# Altium schematic sheet sdflowmeterdisplay2.SchDoc(sdflowmeterdisplay2)
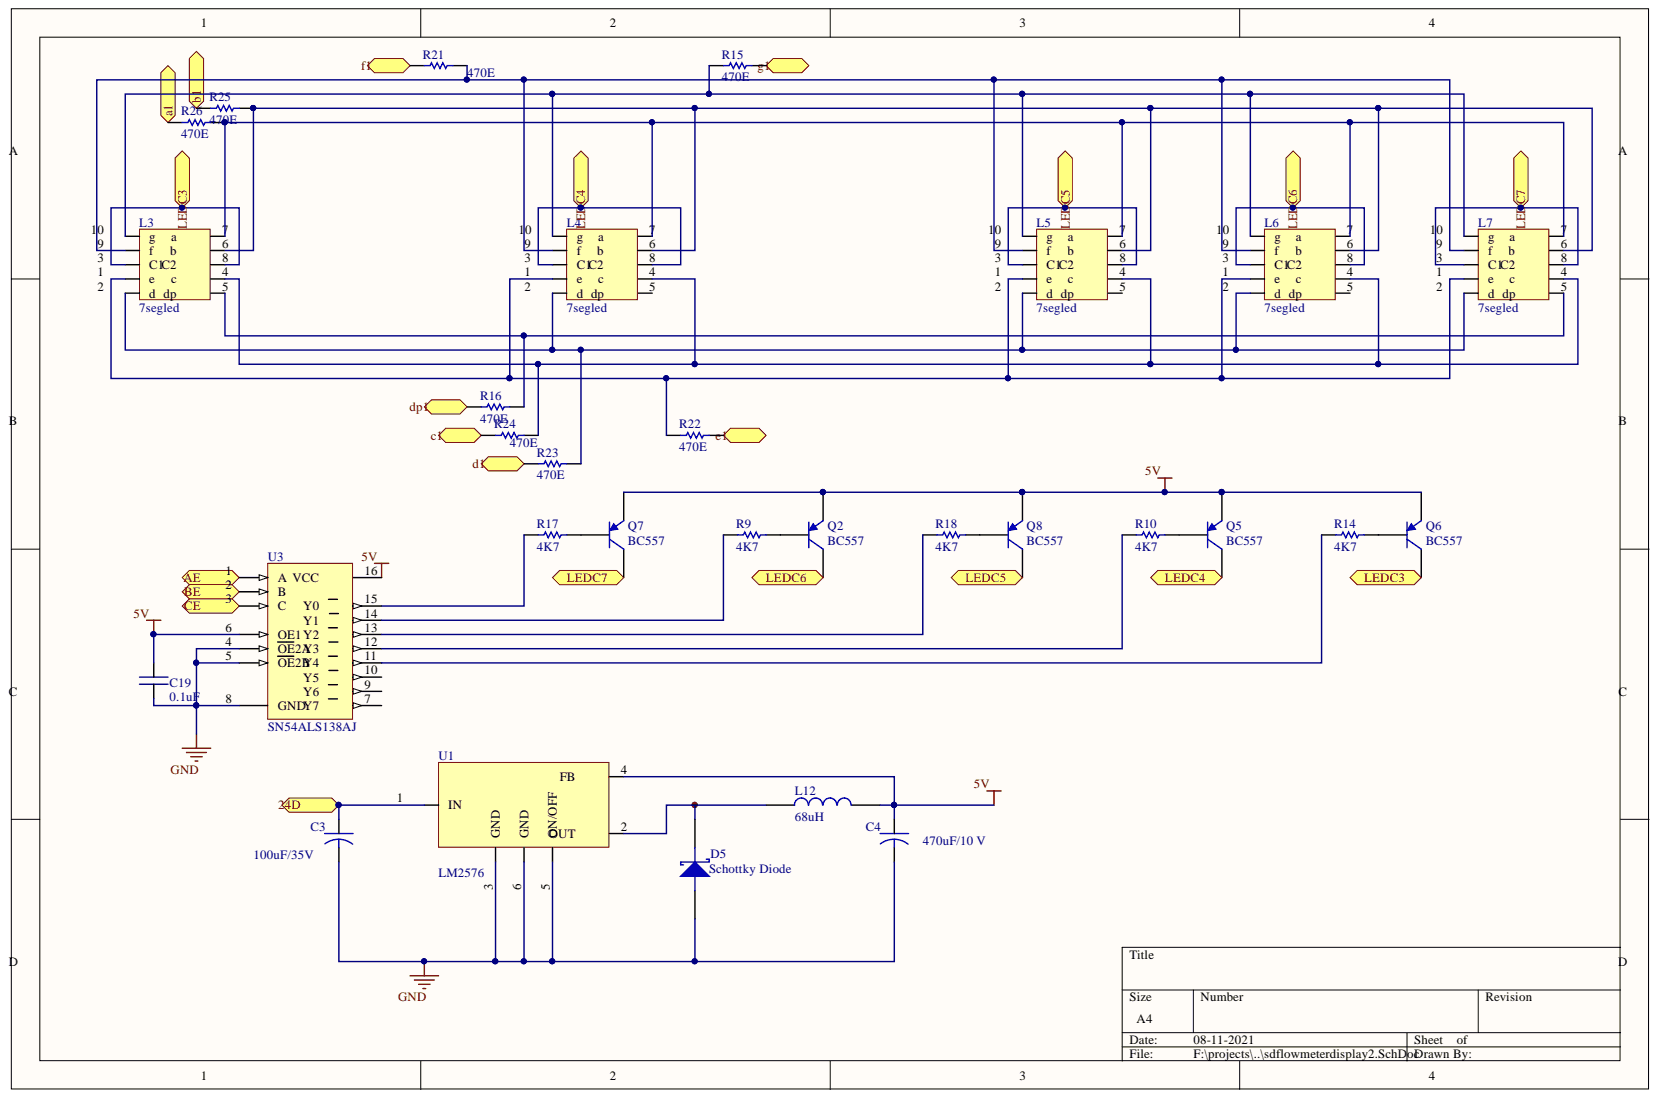
[diagram p0: sdflowmeterdisplay2.SchDoc]

## Parts
C3  100uF/35V  [CAP0.510x17]
C4  470uF/10 V  [CAP0.510x17]
C19  0.1uF  [0805]
D5  Schottky Diode  [SMA]
L3  7segled  [DIP10CCLED]
L4  7segled  [DIP10CCLED]
L5  7segled  [DIP10CCLED]
L6  7segled  [DIP10CCLED]
L7  7segled  [DIP10CCLED]
L12  68uH  [INDMT1]
Q2  BC557  [SOT-23]
Q5  BC557  [SOT-23]
Q6  BC557  [SOT-23]
Q7  BC557  [SOT-23]
Q8  BC557  [SOT-23]
R9  4K7  [0805]
R10  4K7  [0805]
R14  4K7  [0805]
R15  470E  [0805]
R16  470E  [0805]
R17  4K7  [0805]
R18  4K7  [0805]
R21  470E  [0805]
R22  470E  [0805]
R23  470E  [0805]
R24  470E  [0805]
R25  470E  [0805]
R26  470E  [0805]
U1  LM2576  [TO263-5]
U3  SN54ALS138AJ  [SOIC16]

## Ports
24D, A1, AE, B1, BE, C1, CE, D1, DP1, E1, F1, G1, LEDC3, LEDC4, LEDC5, LEDC6, LEDC7

## Nets
5V: C4.1 C19.1 L12.2 Q2.2 Q5.2 Q6.2 Q7.2 Q8.2 U1.4 U3.6 U3.16
GND: C3.2 C4.2 C19.2 D5.1 U1.3(GND) U1.5(ON/OFF) U1.6(GND) U3.4(OE2A) U3.5(OE2B) U3.8(GND)
24D: C3.1 U1.1(IN) ; port 24D
AE: U3.1(A) ; port AE
BE: U3.2(B) ; port BE
CE: U3.3(C) ; port CE
LEDC3: L3.3(C1) L3.8 Q6.3 ; port LEDC3
LEDC4: L4.3(C1) L4.8 Q5.3 ; port LEDC4
LEDC5: L5.3(C1) L5.8 Q8.3 ; port LEDC5
LEDC6: L6.3(C1) L6.8 Q2.3 ; port LEDC6
LEDC7: L7.3(C1) L7.8 Q7.3 ; port LEDC7
NetD5_2: D5.2 L12.1 U1.2
NetL3_1: L3.1(e) L4.1(e) L5.1(e) L6.1(e) L7.1(e) R22.1
NetL3_2: L3.2(d) L4.2(d) L5.2(d) L6.2(d) L7.2(d) R23.2
NetL3_4: L3.4 L4.4 L5.4 L6.4 L7.4 R24.2
NetL3_5: L3.5 L7.5 R16.2
NetL3_6: L3.6 L4.6 L5.6 L6.6 L7.6 R25.2
NetL3_7: L3.7 L4.7 L5.7 L6.7 L7.7 R26.2
NetL3_9: L3.9(f) L4.9(f) L5.9(f) L6.9(f) L7.9(f) R21.2
NetL3_10: L3.10(g) L4.10(g) L5.10(g) L6.10(g) L7.10(g) R15.1
NetQ2_1: Q2.1 R9.2
NetQ5_1: Q5.1 R10.2
NetQ6_1: Q6.1 R14.2
NetQ7_1: Q7.1 R17.2
NetQ8_1: Q8.1 R18.2
NetR9_1: R9.1 U3.14
NetR10_1: R10.1 U3.12
NetR14_1: R14.1 U3.11
NetR15_2: R15.2 ; port G1
NetR16_1: R16.1 ; port DP1
NetR17_1: R17.1 U3.15
NetR18_1: R18.1 U3.13
NetR21_1: R21.1 ; port F1
NetR22_2: R22.2 ; port E1
NetR23_1: R23.1 ; port D1
NetR24_1: R24.1 ; port C1
NetR25_1: R25.1 ; port B1
NetR26_1: R26.1 ; port A1

Not connected: L4 5; L5 5; L6 5; U3 7 9 10

## Library data of the parts
C3 C4 = CAP: Text Field1: 20; Text Field2: 10; Component Kind: Standard
C19 = cap0805: Component Kind: Standard; Library Name: myaltiumsch.SchLib
D5 = DIODE SCHOTTKY: Component Kind: Standard; Description: Schottky Diode
L3-L7 = 7SEGLEDCC: Component Kind: Standard; Library Name: myaltiumsch.SchLib
L12 = INDUCTOR: Component Kind: Standard
Q2 Q5-Q8 = PNP: PNP Bipolar Transistor; Component Kind: Standard; LatestRevisionDate: 29-May-2009; LatestRevisionNote: IPC-7351 Footprint Added.; PackageDescription: 3-Pin SOT-23 Package 0.95 mm Pitch, 2.4 mm Lead Span; PackageReference: SOT-23B; PackageVersion: Aug-1999; Published: 8-Jun-2000; Signal Integrity: PNP; Simulation: PNP; Library Name: myaltiumsch.SchLib; Publisher: Altium Limited
R9 R10 R14-R18 R21-R26 = RES: Resistor; Component Kind: Standard; LatestRevisionDate: 17-Jul-2002; LatestRevisionNote: Re-released for DXP Platform.; PackageReference: AXIAL-0.5; Published: 8-Jun-2000; Signal Integrity: Res Semi; Simulation: RES; Library Name: myaltiumsch.SchLib; Publisher: Altium Limited
U3 = SN54ALS138AJ: 3-Line to 8-Line Decoder / Demultiplexer; Component Kind: Standard; ComponentLink1Description: Manufacturer Link; ComponentLink1URL: http://www.ti.com/; ComponentLink2Description: Datasheet; ComponentLink2URL: http://www-s.ti.com/sc/ds/sn54als138a.pdf; DatasheetVersion: Jul-1996; LatestRevisionDate: 04-Mar-2005; LatestRevisionNote: Stylized 3D Model Added.; PackageDescription: DIP; 16 Leads; Row Spacing 7.62 mm; Pitch 2.54 mm; PackageReference: J016C; PackageVersion: Jun-1999; PCB3D: J016; Published: 8-Jun-2000; Signal Integrity: SN54ALS138AJ; Library Name: TI Logic Decoder Demux.IntLib; Publisher: Altium Limited
U1 = LM2576: Component Kind: Standard; Library Name: myaltiumsch.SchLib
